# Altium schematic sheet [17] - POWER SUPPLIES.SchDoc("[17] - POWER SUPPLIES")
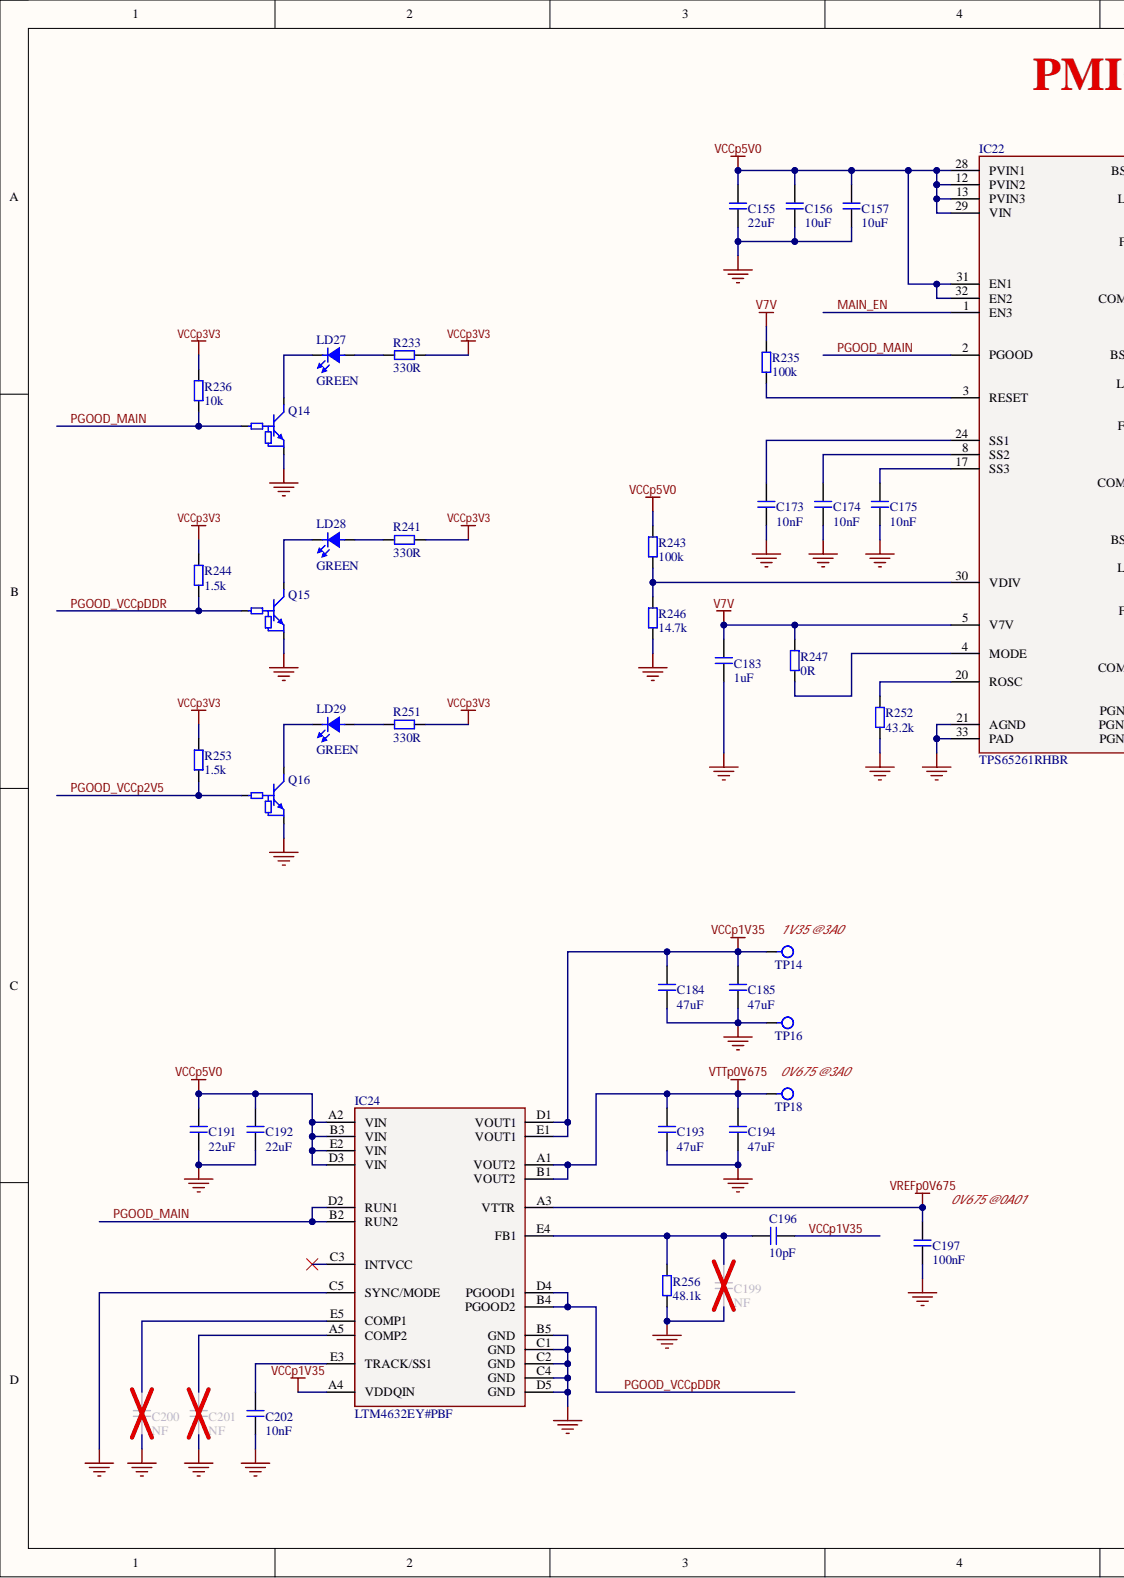
[diagram p0: [17] - POWER SUPPLIES.SchDoc, left]
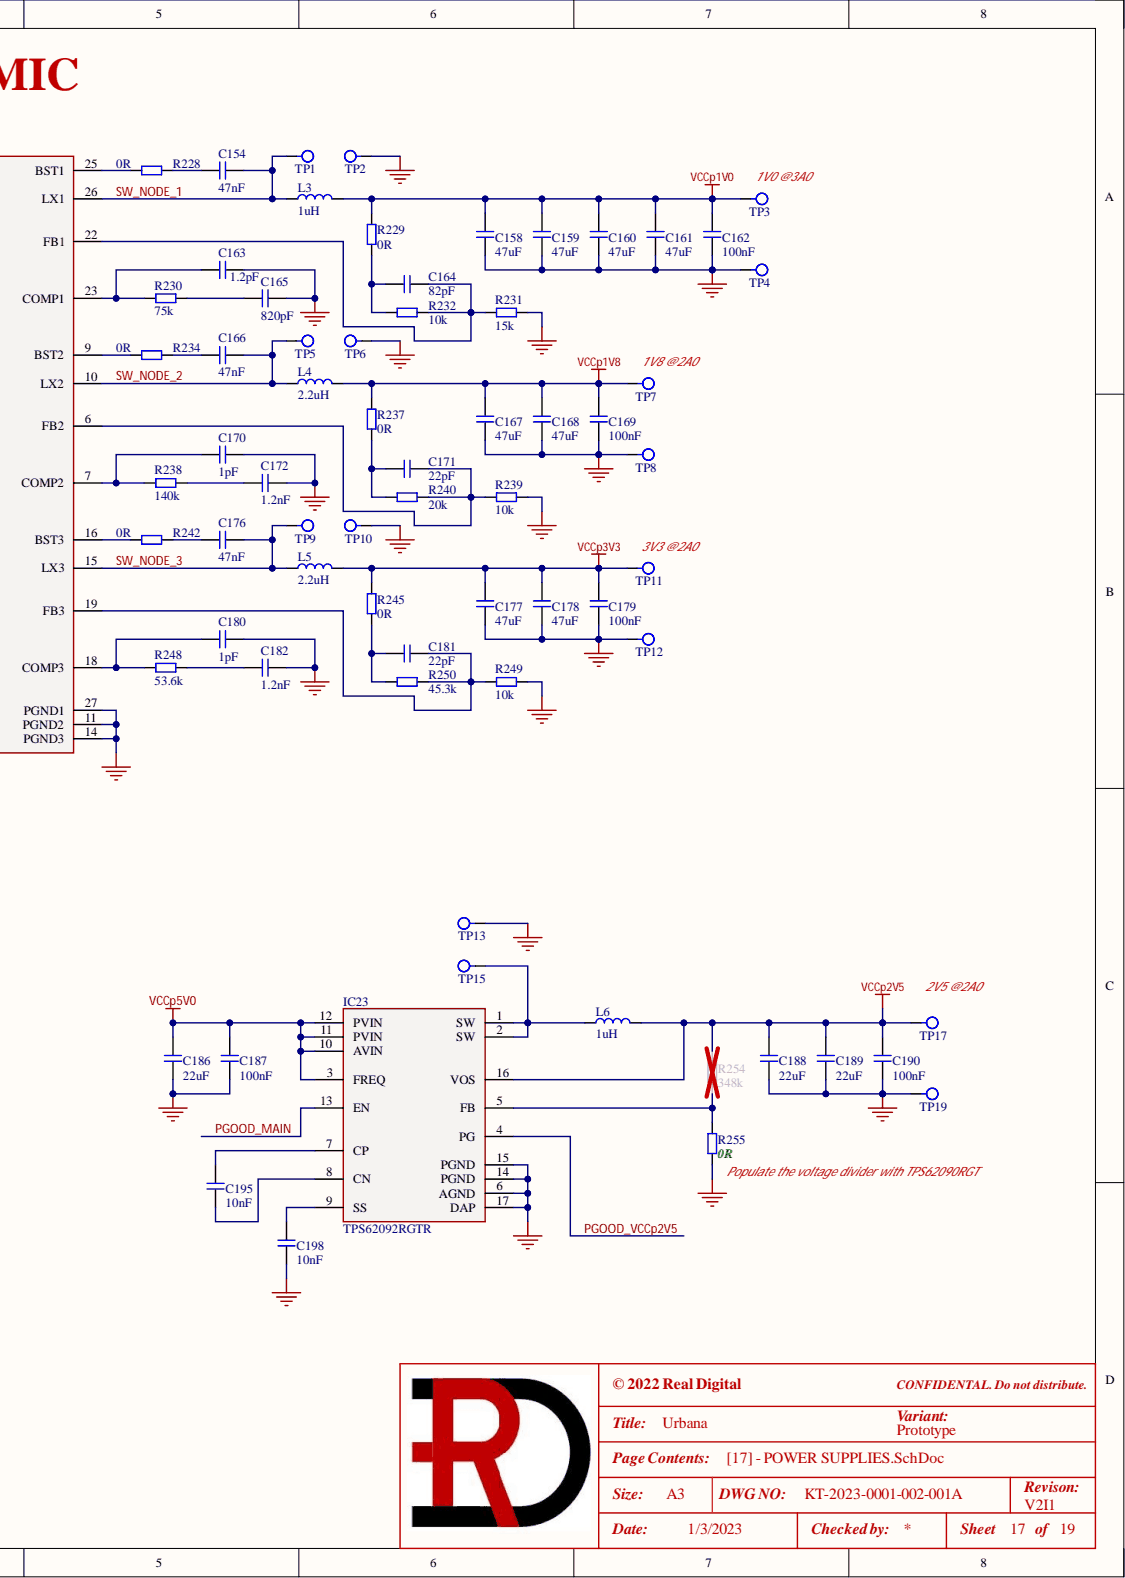
[diagram p1: [17] - POWER SUPPLIES.SchDoc, right]

## Parts
C154  47nF  [C0402]
C155  22uF  [C1210]
C156  10uF  [C1206]
C157  10uF  [C1206]
C158  47uF  [C1210]
C159  47uF  [C1210]
C160  47uF  [C1210]
C161  47uF  [C1210]
C162  100nF  [C0402]
C163  1.2pF  [C0402]
C164  82pF  [C0402]
C165  820pF  [C0402]
C166  47nF  [C0402]
C167  47uF  [C1210]
C168  47uF  [C1210]
C169  100nF  [C0402]
C170  1pF  [C0402]
C171  22pF  [C0402]
C172  1.2nF  [C0402]
C173  10nF  [C0402]
C174  10nF  [C0402]
C175  10nF  [C0402]
C176  47nF  [C0402]
C177  47uF  [C1210]
C178  47uF  [C1210]
C179  100nF  [C0402]
C180  1pF  [C0402]
C181  22pF  [C0402]
C182  1.2nF  [C0402]
C183  1uF  [C0603]
C184  47uF  [C0805]
C185  47uF  [C0805]
C186  22uF  [C0805]
C187  100nF  [C0402]
C188  22uF  [C0805]
C189  22uF  [C0805]
C190  100nF  [C0402]
C191  22uF  [C1210]
C192  22uF  [C1210]
C193  47uF  [C0805]
C194  47uF  [C0805]
C195  10nF  [C0402]
C196  10pF  [C0402]
C197  100nF  [C0402]
C198  10nF  [C0402]
C199  NF  [C0402]
C200  NF  [C0402]
C201  NF  [C0402]
C202  10nF  [C0402]
IC22  TPS65261RHBR  [RHB0032E_VQFN-32 - TI]
IC23  TPS62092RGTR  [RGT0016C - TI]
IC24  LTM4632EY#PBF  [BGA25_05-08-1949 - ADI]
L3  1uH  [BMRx00060630]
L4  2.2uH  [BMRx00060630]
L5  2.2uH  [BMRx00060630]
L6  1uH  [BMRx00060630]
LD27  GREEN  [LD0603_Green]
LD28  GREEN  [LD0603_Green]
LD29  GREEN  [LD0603_Green]
Q14  DTC043ZEBTL  [SC89-EMT3F]  (value not drawn)
Q15  DTC043ZEBTL  [SC89-EMT3F]  (value not drawn)
Q16  DTC043ZEBTL  [SC89-EMT3F]  (value not drawn)
R228  0R  [R0402]
R229  0R  [R0402]
R230  75k  [R0402]
R231  15k  [R0402]
R232  10k  [R0402]
R233  330R  [R0402]
R234  0R  [R0402]
R235  100k  [R0402]
R236  10k  [R0402]
R237  0R  [R0402]
R238  140k  [R0402]
R239  10k  [R0402]
R240  20k  [R0402]
R241  330R  [R0402]
R242  0R  [R0402]
R243  100k  [R0402]
R244  1.5k  [R0402]
R245  0R  [R0402]
R246  14.7k  [R0402]
R247  0R  [R0402]
R248  53.6k  [R0402]
R249  10k  [R0402]
R250  45.3k  [R0402]
R251  330R  [R0402]
R252  43.2k  [R0402]
R253  1.5k  [R0402]
R254  348k  [R0402]
R255  0R  [R0402]
R255
R256  48.1k  [R0603]
TP1  1mm  [TP_1MM]  (value not drawn)  (no BOM)
TP2  1mm  [TP_1MM]  (value not drawn)  (no BOM)
TP3  1.5mm  [TP_1.5MM]  (value not drawn)  (no BOM)
TP4  1.5mm  [TP_1.5MM]  (value not drawn)  (no BOM)
TP5  1mm  [TP_1MM]  (value not drawn)  (no BOM)
TP6  1mm  [TP_1MM]  (value not drawn)  (no BOM)
TP7  1.5mm  [TP_1.5MM]  (value not drawn)  (no BOM)
TP8  1.5mm  [TP_1.5MM]  (value not drawn)  (no BOM)
TP9  1mm  [TP_1MM]  (value not drawn)  (no BOM)
TP10  1mm  [TP_1MM]  (value not drawn)  (no BOM)
TP11  1.5mm  [TP_1.5MM]  (value not drawn)  (no BOM)
TP12  1.5mm  [TP_1.5MM]  (value not drawn)  (no BOM)
TP13  1mm  [TP_1MM]  (value not drawn)  (no BOM)
TP14  1.5mm  [TP_1.5MM]  (value not drawn)  (no BOM)
TP15  1mm  [TP_1MM]  (value not drawn)  (no BOM)
TP16  1.5mm  [TP_1.5MM]  (value not drawn)  (no BOM)
TP17  1.5mm  [TP_1.5MM]  (value not drawn)  (no BOM)
TP18  1.5mm  [TP_1.5MM]  (value not drawn)  (no BOM)
TP19  1.5mm  [TP_1.5MM]  (value not drawn)  (no BOM)

## Nets
GND: C155.1 C156.1 C157.1 C158.1 C159.1 C160.1 C161.1 C162.1 C163.2 C165.2 C167.1 C168.1 C169.1 C170.2 C172.2 C173.1 C174.1 C175.1 C177.1 C178.1 C179.1 C180.2 C182.2 C183.1 C184.1 C185.1 C186.1 C187.1 C188.1 C189.1 C190.1 C191.1 C192.1 C193.1 C194.1 C197.1 C198.1 C199.1 C200.1 C201.1 C202.1 IC22.11(PGND2) IC22.14(PGND3) IC22.21(AGND) IC22.27(PGND1) IC22.33(PAD) IC23.6(AGND) IC23.14(PGND) IC23.15(PGND) IC23.17(DAP) IC24.B5(GND) IC24.C1(GND) IC24.C2(GND) IC24.C4(GND) IC24.C5(SYNC/MODE) IC24.D5(GND) Q14.GND Q15.GND Q16.GND R231.1 R239.1 R246.1 R249.1 R252.1 R255.1 R256.1 TP2.1 TP4.1 TP6.1 TP8.1 TP10.1 TP12.1 TP13.1 TP16.1 TP19.1
V7V: C183.2 IC22.5(V7V) R235.2 R247.2
VCCp1V0: C158.2 C159.2 C160.2 C161.2 C162.2 L3.2 R229.2 TP3.1
VCCp1V8: C167.2 C168.2 C169.2 L4.2 R237.1 TP7.1
VCCp2V5: C188.2 C189.2 C190.2 IC23.16(VOS) L6.2 R254.2 TP17.1
VCCp3V3: C177.2 C178.2 C179.2 L5.2 R233.2 R236.2 R241.2 R244.2 R245.1 R251.2 R253.2 TP11.1
VCCp5V0: C155.2 C156.2 C157.2 C186.2 C187.2 C191.2 C192.2 IC22.12(PVIN2) IC22.13(PVIN3) IC22.28(PVIN1) IC22.29(VIN) IC22.31(EN1) IC22.32(EN2) IC23.3(FREQ) IC23.10(AVIN) IC23.11(PVIN) IC23.12(PVIN) IC24.A2(VIN) IC24.B3(VIN) IC24.D3(VIN) IC24.E2(VIN) R243.2
VREFp0V675: C197.2 IC24.A3(VTTR)
VTTp0V675: C193.2 C194.2 IC24.A1(VOUT2) IC24.B1(VOUT2) TP18.1
MAIN_EN: IC22.1(EN3)
PGOOD_MAIN: IC22.2(PGOOD) IC23.13(EN) IC24.B2(RUN2) IC24.D2(RUN1) Q14.IN R236.1
PGOOD_VCCp2V5: IC23.4(PG) Q16.IN R253.1
PGOOD_VCCpDDR: IC24.B4(PGOOD2) IC24.D4(PGOOD1) Q15.IN R244.1
SW_NODE_1: C154.2 IC22.26(LX1) L3.1 TP1.1
SW_NODE_2: C166.2 IC22.10(LX2) L4.1 TP5.1
SW_NODE_3: C176.2 IC22.15(LX3) L5.1 TP9.1
VCCp1V35: C184.2 C185.2 C196.2 IC24.A4(VDDQIN) IC24.D1(VOUT1) IC24.E1(VOUT1) TP14.1
NetC154_1: C154.1 R228.1
NetC163_1: C163.1 IC22.23(COMP1) R230.1
NetC164_1: C164.1 IC22.22(FB1) R231.2 R232.1
NetC164_2: C164.2 R229.1 R232.2
NetC165_1: C165.1 R230.2
NetC166_1: C166.1 R234.1
NetC170_1: C170.1 IC22.7(COMP2) R238.1
NetC171_1: C171.1 IC22.6(FB2) R239.2 R240.1
NetC171_2: C171.2 R237.2 R240.2
NetC172_1: C172.1 R238.2
NetC173_2: C173.2 IC22.24(SS1)
NetC174_2: C174.2 IC22.8(SS2)
NetC175_2: C175.2 IC22.17(SS3)
NetC176_1: C176.1 R242.1
NetC180_1: C180.1 IC22.18(COMP3) R248.1
NetC181_1: C181.1 IC22.19(FB3) R249.2 R250.1
NetC181_2: C181.2 R245.2 R250.2
NetC182_1: C182.1 R248.2
NetC195_1: C195.1 IC23.8(CN)
NetC195_2: C195.2 IC23.7(CP)
NetC196_1: C196.1 C199.2 IC24.E4(FB1) R256.2
NetC198_2: C198.2 IC23.9(SS)
NetC200_2: C200.2 IC24.E5(COMP1)
NetC201_2: C201.2 IC24.A5(COMP2)
NetC202_2: C202.2 IC24.E3(TRACK/SS1)
NetIC22_3: IC22.3(RESET) R235.1
NetIC22_4: IC22.4(MODE) R247.1
NetIC22_9: IC22.9(BST2) R234.2
NetIC22_16: IC22.16(BST3) R242.2
NetIC22_20: IC22.20(ROSC) R252.2
NetIC22_25: IC22.25(BST1) R228.2
NetIC22_30: IC22.30(VDIV) R243.1 R246.2
NetIC23_1: IC23.1(SW) IC23.2(SW) L6.1 TP15.1
NetIC23_5: IC23.5(FB) R254.1 R255.2
NetLD27_A: LD27.A R233.1
NetLD27_K: LD27.K Q14.OUT
NetLD28_A: LD28.A R241.1
NetLD28_K: LD28.K Q15.OUT
NetLD29_A: LD29.A R251.1
NetLD29_K: LD29.K Q16.OUT

Not connected: IC24 C3(INTVCC)

## Library data of the parts
C154 C166 C176 = GRM155R71E473KA88J: CAP CER 0.047uF 25V X7R 0402; Manufacturer 1: Murata Electronics; .Checked_PCB: AC; .Creator: RV; C P/N: 111-0286; LoadStatus: Load; Tolerance: 10%; Category: Capacitors; Component Kind: Standard; Library Name: KT-RealDigital.IntLib; Manufacturer 1 Part Number: GRM155R71E473KA88J; Temperature Coefficient: X7R; Voltage - Rated: 25V; .Checked_SCH: AC
C155 = GCM32ER71C226ME19K: CAP CER 22uF 16V X7R 1210; Manufacturer 1: Murata Electronics; .Checked_PCB: AC; .Creator: RV; C P/N: RD-111-0010; LoadStatus: Load; Tolerance: ±20%; Category: Capacitors; Component Kind: Standard; Library Name: KT-RealDigital.IntLib; Manufacturer 1 Part Number: GCM32ER71C226ME19K; Temperature Coefficient: X7R; Voltage - Rated: 16V; .Checked_SCH: AC
C156 C157 = GCM31CR71C106KA64K: CAP CER 10uF 16V X7R 1206; Manufacturer 1: Murata Electronics; .Checked_PCB: AC; .Creator: RV; C P/N: RD-111-0011; LoadStatus: Load; Tolerance: 10%; Category: Capacitors; Component Kind: Standard; Library Name: KT-RealDigital.IntLib; Manufacturer 1 Part Number: GCM31CR71C106KA64K; Temperature Coefficient: X7R; Voltage - Rated: 16V; .Checked_SCH: AC
C158-C161 C167 C168 C177 C178 = GRM32EC81C476KE15K: CAP CER 47uF 16V X6S 1210; Manufacturer 1: Murata Electronics; Manufacturer 2 Part Number: GRM32EC81C476KE15L; .Checked_PCB: AC; .Creator: RV; LoadStatus: Load; Tolerance: 10%; Category: Capacitors; Component Kind: Standard; Library Name: KT-RealDigital.IntLib; Manufacturer 1 Part Number: GRM32EC81C476KE15K; Manufacturer 2: Murata Electronics; Temperature Coefficient: X6S; Voltage - Rated: 16V; .Checked_SCH: AC
C162 C169 C179 C187 C190 C197 = GRM155R71E104KE14J: CAP CER 0.1uF 25V X7R 0402; Manufacturer 1: Murata Electronics; .Checked_PCB: AC; .Creator: RV; C P/N: RD-111-0006; LoadStatus: Load; Tolerance: 10%; Category: Capacitors; Component Kind: Standard; Library Name: KT-RealDigital.IntLib; Manufacturer 1 Part Number: GRM155R71E104KE14J; Temperature Coefficient: X7R; Voltage - Rated: 25V; .Checked_SCH: AC
C163 = GJM1555C1H1R2CB01D: CAP CER 1.2pF 50V C0G/NP0 0402; Manufacturer 1: Murata Electronics; .Checked_PCB: AC; .Creator: RV; C P/N: RD-111-0012; LoadStatus: Load; Temperature Coefficient: C0G, NP0; Tolerance: 0.25pF; Category: Capacitors; Component Kind: Standard; Library Name: KT-RealDigital.IntLib; Manufacturer 1 Part Number: GJM1555C1H1R2CB01D; Voltage - Rated: 50V; .Checked_SCH: AC
C164 = GCM1555C1H820FA16D: CAP CER 82pF 50V C0G/NP0 0402; Manufacturer 1: Murata Electronics; .Checked_PCB: AC; .Creator: RV; C P/N: 111-0289; LoadStatus: Load; Temperature Coefficient: C0G, NP0; Tolerance: 1%; Category: Capacitors; Component Kind: Standard; Library Name: KT-RealDigital.IntLib; Manufacturer 1 Part Number: GCM1555C1H820FA16D; Voltage - Rated: 50V; .Checked_SCH: AC
C165 = GRM1555C1H821JA01J: CAP CER 820pF 50V C0G/NP0 0402; Manufacturer 1: Murata Electronics; .Checked_PCB: AC; .Creator: RV; C P/N: RD-111-0013; LoadStatus: Load; Temperature Coefficient: C0G, NP0; Tolerance: 5%; Category: Capacitors; Component Kind: Standard; Library Name: KT-RealDigital.IntLib; Manufacturer 1 Part Number: GRM1555C1H821JA01J; Voltage - Rated: 50V; .Checked_SCH: AC
C170 C180 = GJM1555C1H1R0CB01D: CAP CER 1pF 50V C0G/NP0 0402; Manufacturer 1: Murata Electronics; .Checked_PCB: AC; .Creator: RV; C P/N: RD-111-0014; LoadStatus: Load; Temperature Coefficient: C0G, NP0; Tolerance: 0.25pF; Category: Capacitors; Component Kind: Standard; Library Name: KT-RealDigital.IntLib; Manufacturer 1 Part Number: GJM1555C1H1R0CB01D; Voltage - Rated: 50V; .Checked_SCH: AC
C171 C181 = GCM1555C1H220FA16D: CAP CER 22pF 50V C0G/NP0 0402; Manufacturer 1: Murata Electronics; .Checked_PCB: AC; .Creator: RV; C P/N: RD-111-0015; LoadStatus: Load; Temperature Coefficient: C0G, NP0; Tolerance: 1%; Category: Capacitors; Component Kind: Standard; Library Name: KT-RealDigital.IntLib; Manufacturer 1 Part Number: GCM1555C1H220FA16D; Voltage - Rated: 50V; .Checked_SCH: AC
C172 C182 = GCM155R71H122KA37D: CAP CER 1200pF 50V X7R 0402; Manufacturer 1: Murata Electronics; .Checked_PCB: AC; .Creator: RV; C P/N: RD-111-0002; LoadStatus: Load; Tolerance: 10%; Category: Capacitors; Component Kind: Standard; Library Name: KT-RealDigital.IntLib; Manufacturer 1 Part Number: GCM155R71H122KA37D; Temperature Coefficient: X7R; Voltage - Rated: 50V; .Checked_SCH: AC
C173-C175 C195 C198 C202 = GRM155R71H103KA88J: CAP CER 10000pF 50V X7R 0402; Manufacturer 1: Murata Electronics; .Checked_PCB: AC; .Creator: RV; C P/N: RD-111-0003; LoadStatus: Load; Tolerance: 10%; Category: Capacitors; Component Kind: Standard; Library Name: KT-RealDigital.IntLib; Manufacturer 1 Part Number: GRM155R71H103KA88J; Temperature Coefficient: X7R; Voltage - Rated: 50V; .Checked_SCH: AC
C183 = GCJ188R71E105KA01D: CAP CER 1uF 25V X7R 0603; Manufacturer 1: Murata Electronics; .Checked_PCB: AC; .Creator: RV; C P/N: 111-0602; LoadStatus: Load; Tolerance: 10%; Category: Capacitors; Component Kind: Standard; Library Name: KT-RealDigital.IntLib; Manufacturer 1 Part Number: GCJ188R71E105KA01D; Temperature Coefficient: X7R; Voltage - Rated: 25V; .Checked_SCH: AC
C184 C185 C193 C194 = GRM21BR61A476ME15L: CAP CER 47uF 10V X5R 0805; Manufacturer 1: Murata Electronics; .Checked_PCB: RV; LoadStatus: Load; Tolerance: 20%; Category: Capacitors; Component Kind: Standard; Library Name: KT-RealDigital.IntLib; Manufacturer 1 Part Number: GRM21BR61A476ME15L; Temperature Coefficient: X5R; Voltage - Rated: 10V; .Checked_SCH: RV; .Creator: RV
C186 C188 C189 = GRM21BR61E226ME44K: CAP CER 22uF 25V X5R 0805; Manufacturer 1: Murata Electronics; .Checked_PCB: RV; LoadStatus: Load; Tolerance: 20%; Category: Capacitors; Component Kind: Standard; Library Name: KT-RealDigital.IntLib; Manufacturer 1 Part Number: GRM21BR61E226ME44K; Temperature Coefficient: X5R; Voltage - Rated: 25V; .Checked_SCH: RV; .Creator: RV
C191 C192 = GRM32ER71E226ME15K: CAP CER 22uF 25V X7R 1210; Manufacturer 1: Murata Electronics; .Checked_PCB: AC; .Creator: RV; LoadStatus: Load; Tolerance: 20%; Category: Capacitors; Component Kind: Standard; Library Name: KT-RealDigital.IntLib; Manufacturer 1 Part Number: GRM32ER71E226ME15K; Temperature Coefficient: X7R; Voltage - Rated: 25V; .Checked_SCH: AC
C196 = GCM1555C1H100GA16D: CAP CER 10pF 50V C0G/NP0 0402; Manufacturer 1: Murata Electronics; .Checked_PCB: RV; LoadStatus: Load; Temperature Coefficient: C0G, NP0; Tolerance: 2%; Category: Capacitors; Component Kind: Standard; Library Name: KT-RealDigital.IntLib; Manufacturer 1 Part Number: GCM1555C1H100GA16D; Voltage - Rated: 50V; .Checked_SCH: RV; .Creator: RV
C199-C201 = !CAP0402: Generic Capacitor, 0402; .Creator: RV; LoadStatus: Load; Category: Capacitors; Component Kind: Standard; Library Name: KT-RealDigital.IntLib
IC22 = TPS65261RHBR: IC REG BCK ADJ 3A/2A TRPL 32VQFN; Manufacturer 1: Texas Instruments; .Checked_PCB: AC; .Creator: RV; C P/N: RD-126-0005; LoadStatus: Load; Category: IC; Component Kind: Standard; Library Name: KT-RealDigital.IntLib; Manufacturer 1 Part Number: TPS65261RHBR; .Checked_SCH: AC
IC23 = TPS62092RGTR: IC REG BUCK 2.5V 3A 16QFN; Manufacturer 1: Texas Instruments; .Checked_PCB: RV; LoadStatus: Load; Category: IC; Component Kind: Standard; Library Name: KT-RealDigital.IntLib; Manufacturer 1 Part Number: TPS62092RGTR; .Checked_SCH: RV; .Creator: RV
IC24 = LTM4632EY#PBF: DC DC CNVRTR 0.6-2.5V 0.6-2.5V; Manufacturer 1: Analog Devices Inc.; .Checked_PCB: RV; LoadStatus: Load; Category: IC; Component Kind: Standard; Library Name: KT-RealDigital.IntLib; Manufacturer 1 Part Number: LTM4632EY#PBF; .Checked_SCH: RV; .Creator: RV
L3 L6 = BMRA000606301R0MA1: INDUCTOR, PWR; Manufacturer 1: Chilisin Electronics; .Checked_PCB: AC; .Creator: RV; C P/N: RD-131-0002; LoadStatus: Load; Category: Inductors; Component Kind: Standard; Library Name: KT-RealDigital.IntLib; Manufacturer 1 Part Number: BMRA000606301R0MA1; .Checked_SCH: AC
L4 L5 = BMRA000606302R2MA1: INDUCTOR, PWR; Manufacturer 1: Chilisin Electronics; .Checked_PCB: AC; .Creator: RV; C P/N: RD-131-0001; LoadStatus: Load; Category: Inductors; Component Kind: Standard; Library Name: KT-RealDigital.IntLib; Manufacturer 1 Part Number: BMRA000606302R2MA1; .Checked_SCH: AC
LD27-LD29 = LED_GREEN: LED GREEN 0603; Manufacturer 1: Everlight Electronics CO., LTD; Manufacturer 1 Part Number: 19-21SYGC/S530-E4/TR8; .Checked_PCB: AC; .Creator: RV; C P/N: 121-0006; LoadStatus: Load; Category: Led; Component Kind: Standard; Library Name: KT-RealDigital.IntLib; .Checked_SCH: AC
Q14-Q16 = DTC043ZEBTL: TRANS PREBIAS NPN 50V EMT3F; Manufacturer 1: Rohm Semiconductor; .Checked_PCB: RV; LoadStatus: Load; Category: BJTs; Component Kind: Standard; Library Name: KT-RealDigital.IntLib; Manufacturer 1 Part Number: DTC043ZEBTL; .Checked_SCH: RV; .Creator: RV
R228 R229 R234 R237 R242 R245 R247 R255 = RC0402FR-070RL: RES SMD 0 OHM JUMPER 1/16W 0402; Manufacturer 1: Yageo; .Checked_PCB: AC; .Creator: RV; C P/N: RD-101-0003; LoadStatus: Load; Category: Resistors; Component Kind: Standard; Library Name: KT-RealDigital.IntLib; Manufacturer 1 Part Number: RC0402FR-070RL; Tolerance: Jumper; .Checked_SCH: AC
R230 = RC0402FR-0775KL: RES SMD 75K OHM 1% 1/16W 0402; Manufacturer 1: Yageo; .Checked_PCB: AC; .Creator: RV; C P/N: 101-1083; LoadStatus: Load; Category: Resistors; Component Kind: Standard; Library Name: KT-RealDigital.IntLib; Manufacturer 1 Part Number: RC0402FR-0775KL; Tolerance: 1%; .Checked_SCH: AC
R231 = RT0402BRD0715KL: RES SMD 15K OHM 0.1% 1/16W 0402; Manufacturer 1: Yageo; .Checked_PCB: AC; .Creator: RV; C P/N: 101-0867; LoadStatus: Load; Category: Resistors; Component Kind: Standard; Library Name: KT-RealDigital.IntLib; Manufacturer 1 Part Number: RT0402BRD0715KL; Tolerance: 0.1%; .Checked_SCH: AC
R232 R239 R249 = RT0402BRB0710KL: RES SMD 10K OHM 0.1% 1/16W 0402; Manufacturer 1: Yageo; .Checked_PCB: AC; .Creator: RV; C P/N: 101-0862; LoadStatus: Load; Category: Resistors; Component Kind: Standard; Library Name: KT-RealDigital.IntLib; Manufacturer 1 Part Number: RT0402BRB0710KL; Tolerance: 0.1%; .Checked_SCH: AC
R233 R241 R251 = RC0402JR-07330RL: RES SMD 330 OHM 5% 1/16W 0402; Manufacturer 1: Yageo; .Checked_PCB: AC; .Creator: RV; C P/N: 101-0112; LoadStatus: Load; Category: Resistors; Component Kind: Standard; Library Name: KT-RealDigital.IntLib; Manufacturer 1 Part Number: RC0402JR-07330RL; Tolerance: 5%; .Checked_SCH: AC
R235 R243 = RC0402JR-07100KL: RES SMD 100K OHM 5% 1/16W 0402; Manufacturer 1: Yageo; .Checked_PCB: AC; .Creator: RV; C P/N: 101-0046; LoadStatus: Load; Category: Resistors; Component Kind: Standard; Library Name: KT-RealDigital.IntLib; Manufacturer 1 Part Number: RC0402JR-07100KL; Tolerance: 5%; .Checked_SCH: AC
R236 = RC0402FR-0710KL: RES SMD 10K OHM 1% 1/16W 0402; Manufacturer 1: Yageo; .Checked_PCB: AC; .Creator: RV; LoadStatus: Load; Category: Resistors; Component Kind: Standard; Library Name: KT-RealDigital.IntLib; Manufacturer 1 Part Number: RC0402FR-0710KL; Tolerance: 1%; .Checked_SCH: AC
R238 = RC0402FR-07140KL: RES SMD 140K OHM 1% 1/16W 0402; Manufacturer 1: Yageo; .Checked_PCB: AC; .Creator: RV; C P/N: 101-0466; LoadStatus: Load; Category: Resistors; Component Kind: Standard; Library Name: KT-RealDigital.IntLib; Manufacturer 1 Part Number: RC0402FR-07140KL; Tolerance: 1%; .Checked_SCH: AC
R240 = RT0402BRD0720KL: RES SMD 20K OHM 0.1% 1/16W 0402; Manufacturer 1: Yageo; .Checked_PCB: AC; .Creator: RV; C P/N: 101-1361; LoadStatus: Load; Category: Resistors; Component Kind: Standard; Library Name: KT-RealDigital.IntLib; Manufacturer 1 Part Number: RT0402BRD0720KL; Tolerance: 0.1%; .Checked_SCH: AC
R244 R253 = RC0402FR-071K5L: RES 1.5K OHM 1% 1/16W 0402; Manufacturer 1 Part Number: YAGEO; .Checked_PCB: RV; LoadStatus: Load; Category: Resistors; Component Kind: Standard; Library Name: KT-RealDigital.IntLib; Manufacturer 1: RC0402FR-071K5L; Tolerance: 1%; .Checked_SCH: RV; .Creator: RV
R246 = RC0402FR-0714K7L: RES SMD 14.7K OHM 1% 1/16W 0402; Manufacturer 1: Yageo; .Checked_PCB: AC; .Creator: RV; C P/N: 101-0493; LoadStatus: Load; Category: Resistors; Component Kind: Standard; Library Name: KT-RealDigital.IntLib; Manufacturer 1 Part Number: RC0402FR-0714K7L; Tolerance: 1%; .Checked_SCH: AC
R248 = RC0402FR-0753K6L: RES SMD 53.6K OHM 1% 1/16W 0402; Manufacturer 1: Yageo; .Checked_PCB: AC; .Creator: RV; C P/N: 101-0491; LoadStatus: Load; Category: Resistors; Component Kind: Standard; Library Name: KT-RealDigital.IntLib; Manufacturer 1 Part Number: RC0402FR-0753K6L; Tolerance: 1%; .Checked_SCH: AC
R250 = RT0402BRD0745K3L: RES SMD 45.3KOHM 0.1% 1/16W 0402; Manufacturer 1: Yageo; .Checked_PCB: AC; .Creator: RV; C P/N: RD-101-0004; LoadStatus: Load; Category: Resistors; Component Kind: Standard; Library Name: KT-RealDigital.IntLib; Manufacturer 1 Part Number: RT0402BRD0745K3L; Tolerance: 0.1%; .Checked_SCH: AC
R252 = RC0402FR-0743K2L: RES SMD 43.2K OHM 1% 1/16W 0402; Manufacturer 1: Yageo; .Checked_PCB: AC; .Creator: RV; C P/N: 101-0497; LoadStatus: Load; Category: Resistors; Component Kind: Standard; Library Name: KT-RealDigital.IntLib; Manufacturer 1 Part Number: RC0402FR-0743K2L; Tolerance: 1%; .Checked_SCH: AC
R254 = RE0402BRE07348KL: RES SMD 348K OHM 0.1% 1/16W 0402; Manufacturer 1: YAGEO; .Creator: RV; LoadStatus: Load; Category: Resistors; Component Kind: Standard; Library Name: KT-RealDigital.IntLib; Manufacturer 1 Part Number: RE0402BRE07348KL; Tolerance: 0.1%
R256 = RT0603BRD0748K1L: RES SMD 48.1KOHM 0.1% 1/10W 0603; Manufacturer 1: Yageo; .Checked_PCB: RV; LoadStatus: Load; Category: Resistors; Component Kind: Standard; Library Name: KT-RealDigital.IntLib; Manufacturer 1 Part Number: RT0603BRD0748K1L; Tolerance: 0.1%; .Checked_SCH: RV; .Creator: RV
TP1 TP2 TP5 TP6 TP9 TP10 TP13 TP15 = TEST_POINT_1mm: TEST POINT round 1mm; Manufacturer 1: NA; .Checked_PCB: RV; LoadStatus: Load; Category: Test Point; Library Name: KT-RealDigital.IntLib; Manufacturer 1 Part Number: NA; Manufacturer 2: NA; Manufacturer 2 Part Number: NA; .Checked_SCH: RV; .Creator: RV
TP3 TP4 TP7 TP8 TP11 TP12 TP14 TP16-TP19 = TEST_POINT_1.5mm: TEST POINT - Round 1.5mm; Manufacturer 1: NA; .Checked_PCB: RV; LoadStatus: Load; Category: Test Point; Library Name: KT-RealDigital.IntLib; Manufacturer 1 Part Number: NA; Manufacturer 2: NA; Manufacturer 2 Part Number: NA; .Checked_SCH: RV; .Creator: RV
